# Altium schematic sheet Psoc.SchDoc
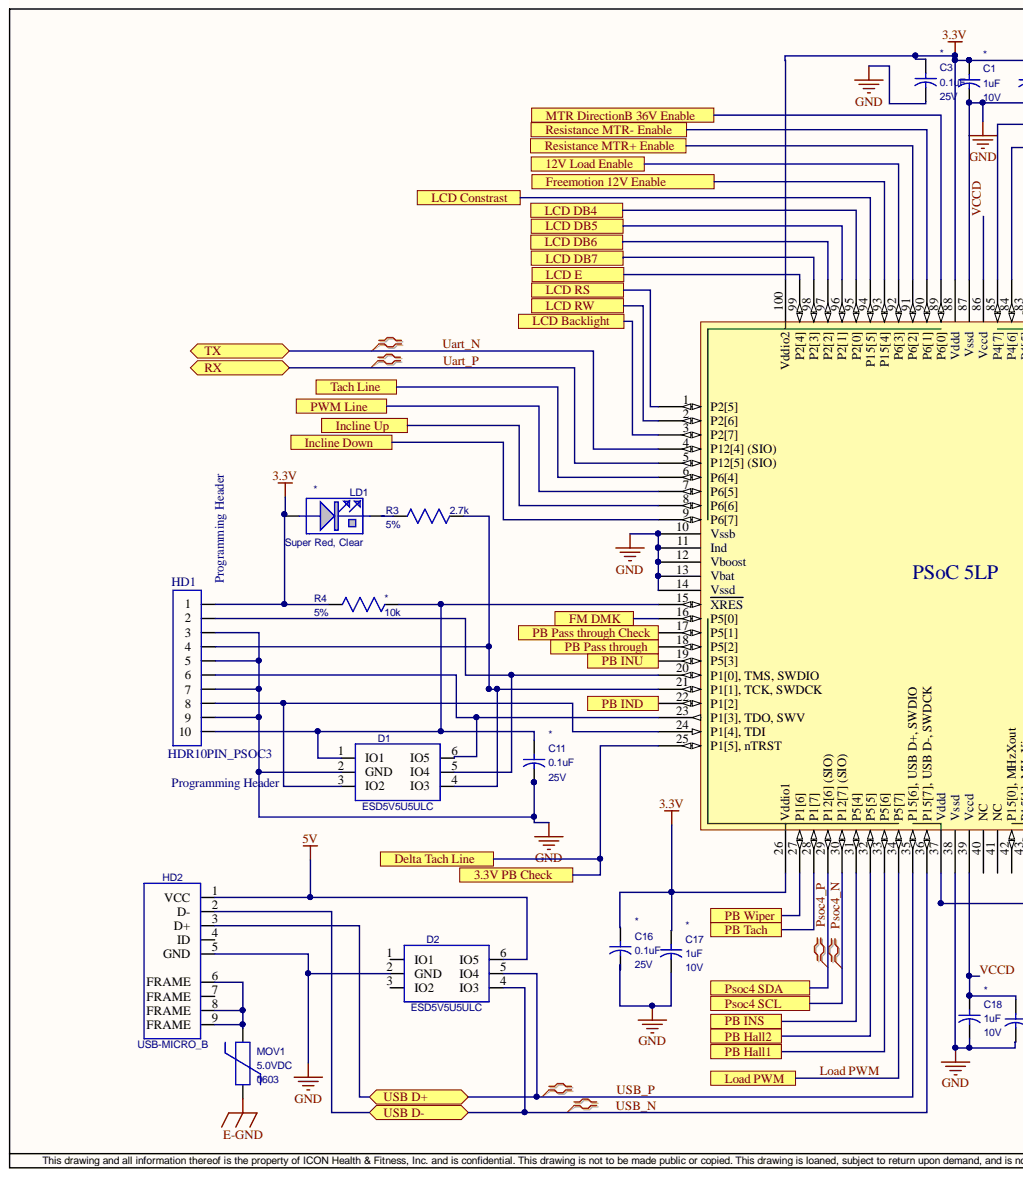
[diagram p0: Psoc.SchDoc, left]
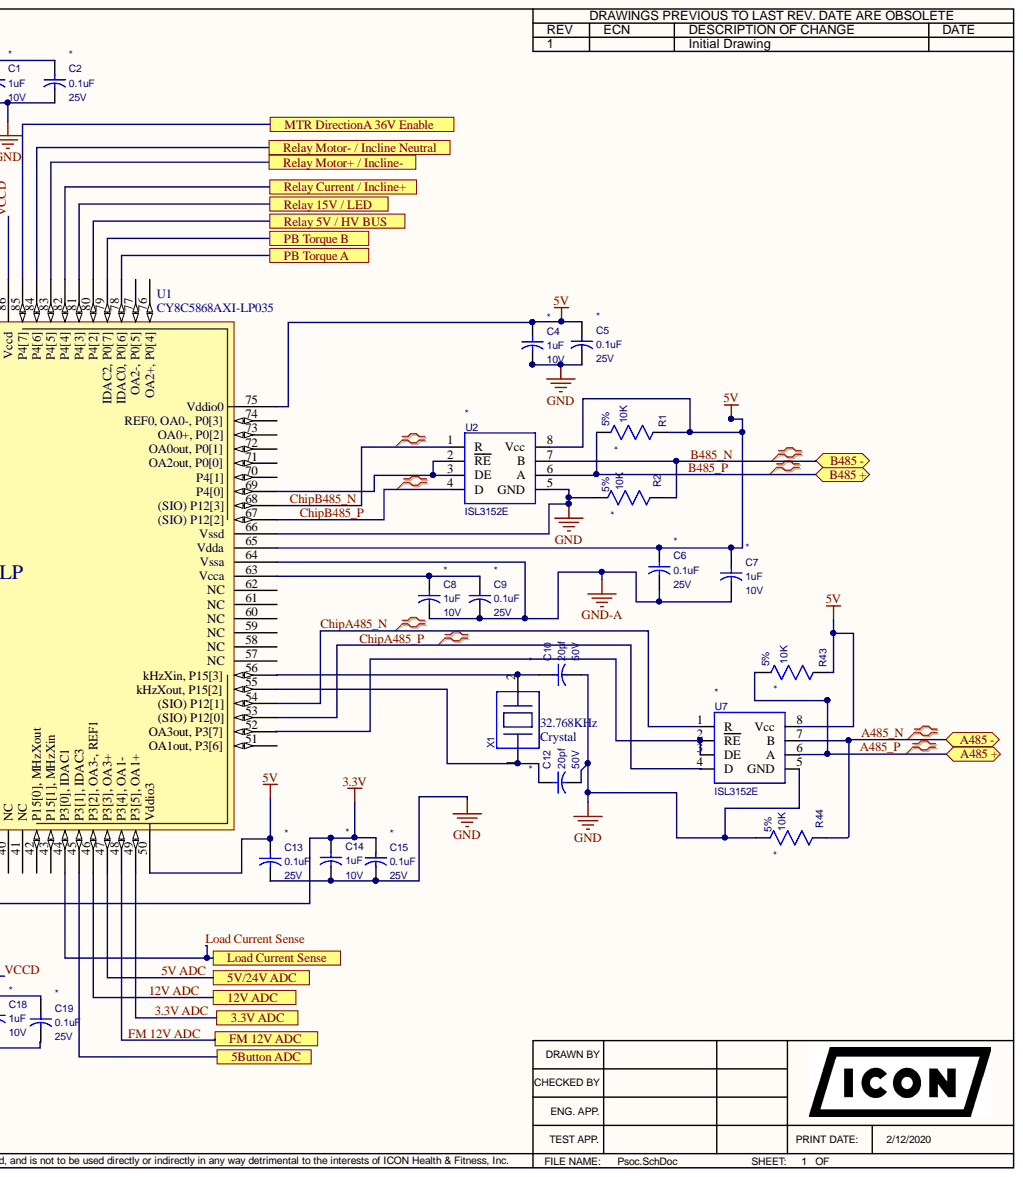
[diagram p1: Psoc.SchDoc, right]

## Parts
C1  value 1uF  Voltage: 10V  [0201]  (hidden comment: CAP_NON_POLAR_SMT)
C2  value 0.1uF  Voltage: 25V  [C0201]  (hidden comment: CAP_NON_POLAR_SMT)
C3  value 0.1uF  Voltage: 25V  [C0201]  (hidden comment: CAP_NON_POLAR_SMT)
C4  value 1uF  Voltage: 10V  [0201]  (hidden comment: CAP_NON_POLAR_SMT)
C5  value 0.1uF  Voltage: 25V  [C0201]  (hidden comment: CAP_NON_POLAR_SMT)
C6  value 0.1uF  Voltage: 25V  [C0201]  (hidden comment: CAP_NON_POLAR_SMT)
C7  value 1uF  Voltage: 10V  [0201]  (hidden comment: CAP_NON_POLAR_SMT)
C8  value 1uF  Voltage: 10V  [0201]  (hidden comment: CAP_NON_POLAR_SMT)
C9  value 0.1uF  Voltage: 25V  [C0201]  (hidden comment: CAP_NON_POLAR_SMT)
C10  value 20pf  Voltage: 50V  [0201_0603]  (hidden comment: 20pF_0201)
C11  value 0.1uF  Voltage: 25V  [C0201]  (hidden comment: CAP_NON_POLAR_SMT)
C12  value 20pf  Voltage: 50V  [0201_0603]  (hidden comment: 20pF_0201)
C13  value 0.1uF  Voltage: 25V  [C0201]  (hidden comment: CAP_NON_POLAR_SMT)
C14  value 1uF  Voltage: 10V  [0201]  (hidden comment: CAP_NON_POLAR_SMT)
C15  value 0.1uF  Voltage: 25V  [C0201]  (hidden comment: CAP_NON_POLAR_SMT)
C16  value 0.1uF  Voltage: 25V  [C0201]  (hidden comment: CAP_NON_POLAR_SMT)
C17  value 1uF  Voltage: 10V  [0201]  (hidden comment: CAP_NON_POLAR_SMT)
C18  value 1uF  Voltage: 10V  [0201]  (hidden comment: CAP_NON_POLAR_SMT)
C19  value 0.1uF  Voltage: 25V  [C0201]  (hidden comment: CAP_NON_POLAR_SMT)
D1  ESD5V5U5ULC  [SOT457-6]
D2  ESD5V5U5ULC  [SOT457-6]
HD1  HDR10PIN_PSOC3  ComponentType: Header  Notes: Programming Header  [HDR10PIN_PSOC3]
HD2  USB-MICRO_B  [HD_USB_MICRO_B_5PIN]
LD1  Color: Super Red, Clear  [0603_LED]  (hidden comment: 0603_RED)
MOV1  Package: 0603  Voltage: 5.0VDC  [0603 MOV]  (hidden comment: MOV 0603 5.5VDC)
R1  value 10K  Tolerance: 5%  [RC0603REFLOW-IPC]  (hidden comment: R0603REFLOW_5%)
R2  value 10K  Tolerance: 5%  [RC0603REFLOW-IPC]  (hidden comment: R0603REFLOW_5%)
R3  value 2.7k  Tolerance: 5%  [0402]  (hidden comment: Resistor_0402_5%)
R4  value 10k  Tolerance: 5%  [0402]  (hidden comment: RESISTOR_SMT_5%)
R43  value 10K  Tolerance: 5%  [RC0603REFLOW-IPC]  (hidden comment: R0603REFLOW_5%)
R44  value 10K  Tolerance: 5%  [RC0603REFLOW-IPC]  (hidden comment: R0603REFLOW_5%)
U1  CY8C5868AXI-LP035  [TQFP-100_16x16-MD]
U2  value ISL3152E  [MSOP8]  (hidden comment: IC_RS485_TRANSCEIVER)
U7  value ISL3152E  [MSOP8]  (hidden comment: IC_RS485_TRANSCEIVER)
X1  Frequency: 32.768KHz  XtalType: Crystal  [SMD2x1.2]  (hidden comment: 8MHZ_CRYSTAL_4PIN_SMT)

## Ports
3.3V ADC, 3.3V PB Check, 5Button ADC, 5V/24V ADC, 12V ADC, 12V Load Enable, A485 +, A485 -, B485 +, B485 -, Delta Tach Line, FM 12V ADC, FM DMK, Freemotion 12V Enable, Incline Down, Incline Up, LCD Backlight, LCD Constrast, LCD DB4, LCD DB5, LCD DB6, LCD DB7, LCD E, LCD RS, LCD RW, Load Current Sense, Load PWM, MTR DirectionA 36V Enable, MTR DirectionB 36V Enable, PB Hall1, PB Hall2, PB IND, PB INS, PB INU, PB Pass through, PB Pass through Check, PB Tach, PB Torque A, PB Torque B, PB Wiper, Psoc4 SCL, Psoc4 SDA, PWM Line, Relay 5V / HV BUS, Relay 15V / LED, Relay Current / Incline+, Relay Motor+ / Incline-, Relay Motor- / Incline Neutral, Resistance MTR+ Enable, Resistance MTR- Enable, RX, Tach Line, TX, USB D+, USB D-

## Nets
3.3V: C1.1 C2.1 C3.1 C14.1 C15.1 C16.1 C17.1 HD1.1 LD1.2 R4.2 U1.26(Vddio1) U1.37(Vddd) U1.88(Vddd) U1.100(Vddio2)
5V: C4.1 C5.1 C6.1 C7.1 C13.1 D2.6(IO5) HD2.1(VCC) R1.2 R43.2 U1.50(Vddio3) U1.65(Vdda) U1.75(Vddio0) U2.8(Vcc) U7.8(Vcc)
E-GND: MOV1.2
GND: C1.2 C2.2 C3.2 C4.2 C5.2 C10.2 C11.2 C12.2 C13.2 C14.2 C15.2 C16.2 C17.2 C18.2 C19.2 D1.2(GND) D2.2(GND) HD1.3 HD1.5 HD1.7 HD1.9 HD2.5(GND) R2.1 R44.1 U1.10(Vssb) U1.11(Ind) U1.12(Vboost) U1.13(Vbat) U1.14(Vssd) U1.38(Vssd) U1.66(Vssd) U1.87(Vssd) U2.5(GND) U7.5(GND)
GND-A: C6.2 C7.2 C8.2 C9.2 U1.64(Vssa)
3.3V ADC: U1.49(P3[5],_OA1+) ; port 3.3V ADC
3.3V PB Check: U1.25(P1[5],_nTRST) ; port 3.3V PB Check, port Delta Tach Line
5Button ADC: U1.45(P3[1],_IDAC3) ; port 5Button ADC
5V ADC: U1.47(P3[3],_OA3+) ; port 5V/24V ADC
12V ADC: U1.46(P3[2],_OA3-,_REF1) ; port 12V ADC
12V Load Enable: U1.92(P6[3]) ; port 12V Load Enable
A485_N: R44.2 U7.7(B)
A485_P: R43.1 U7.6(A)
B485_N: R2.2 U2.7(B)
B485_P: R1.1 U2.6(A)
ChipA485_N: U1.54((SIO)_P12[1]) U7.1(R)
ChipA485_P: U1.53((SIO)_P12[0]) U7.4(D)
ChipB485_N: U1.68((SIO)_P12[3]) U2.1(R)
ChipB485_P: U1.67((SIO)_P12[2]) U2.4(D)
FM 12V ADC: U1.48(P3[4],_OA1-) ; port FM 12V ADC
FM DMK: U1.16(P5[0]) ; port FM DMK
Freemotion 12V Enable: U1.93(P15[4]) ; port Freemotion 12V Enable
Incline Down: U1.9(P6[7]) ; port Incline Down
Incline Up: U1.8(P6[6]) ; port Incline Up
LCD Backlight: U1.3(P2[7]) ; port LCD Backlight
LCD Constrast: U1.94(P15[5]) ; port LCD Constrast
LCD DB4: U1.95(P2[0]) ; port LCD DB4
LCD DB5: U1.96(P2[1]) ; port LCD DB5
LCD DB6: U1.97(P2[2]) ; port LCD DB6
LCD DB7: U1.98(P2[3]) ; port LCD DB7
LCD E: U1.99(P2[4]) ; port LCD E
LCD RS: U1.1(P2[5]) ; port LCD RS
LCD RW: U1.2(P2[6]) ; port LCD RW
Load Current Sense: U1.44(P3[0],_IDAC1) ; port Load Current Sense
Load PWM: U1.34(P5[7]) ; port Load PWM
MTR DirectionA 36V Enable: U1.85(P4[7]) ; port MTR DirectionA 36V Enable
MTR DirectionB 36V Enable: U1.89(P6[0]) ; port MTR DirectionB 36V Enable
PB Hall1: U1.33(P5[6]) ; port PB Hall1
PB Hall2: U1.32(P5[5]) ; port PB Hall2
PB IND: U1.22(P1[2]) ; port PB IND
PB INS: U1.31(P5[4]) ; port PB INS
PB INU: U1.19(P5[3]) ; port PB INU
PB Pass through: U1.18(P5[2]) ; port PB Pass through
PB Pass through Check: U1.17(P5[1]) ; port PB Pass through Check
PB Tach: U1.28(P1[7]) ; port PB Tach
PB Torque A: U1.78(IDAC0,_P0[6]) ; port PB Torque A
PB Torque B: U1.79(IDAC2,_P0[7]) ; port PB Torque B
PB Wiper: U1.27(P1[6]) ; port PB Wiper
Psoc4_N: U1.30(P12[7]_(SIO)) ; port Psoc4 SCL
Psoc4_P: U1.29(P12[6]_(SIO)) ; port Psoc4 SDA
PWM Line: U1.7(P6[5]) ; port PWM Line
Relay 5V / HV BUS: U1.80(P4[2]) ; port Relay 5V / HV BUS
Relay 15V / LED: U1.81(P4[3]) ; port Relay 15V / LED
Relay Current / Incline+: U1.82(P4[4]) ; port Relay Current / Incline+
Relay Motor+ / Incline-: U1.83(P4[5]) ; port Relay Motor+ / Incline-
Relay Motor- / Incline Neutral: U1.84(P4[6]) ; port Relay Motor- / Incline Neutral
Tach Line: U1.6(P6[4]) ; port Tach Line
Uart_N: U1.4(P12[4]_(SIO)) ; port TX
Uart_P: U1.5(P12[5]_(SIO)) ; port RX
USB_N: D2.4(IO3) HD2.2(D-) U1.36(P15[7],_USB_D-,_SWDCK)
USB_P: D2.5(IO4) HD2.3(D+) U1.35(P15[6],_USB_D+,_SWDIO)
VCCD: C18.1 C19.1 U1.39(Vccd) U1.86(Vccd)
NetC8_1: C8.1 C9.1 U1.63(Vcca)
NetC10_1: C10.1 U1.56(kHzXin,_P15[3]) X1.2
NetC11_1: C11.1 D1.1(IO1) HD1.10 R4.1 U1.15(XRES)
NetC12_1: C12.1 U1.55(kHzXout,_P15[2]) X1.1
NetD1_3: D1.3(IO2) HD1.8 U1.24(P1[4],_TDI)
NetD1_4: D1.4(IO3) HD1.4 R3.1 U1.21(P1[1],_TCK,_SWDCK)
NetD1_5: D1.5(IO4) HD1.2 U1.20(P1[0],_TMS,_SWDIO)
NetD1_6: D1.6(IO5) HD1.6 U1.23(P1[3],_TDO,_SWV)
NetHD2_6: HD2.6(FRAME) HD2.8(FRAME) HD2.9(FRAME) MOV1.1
NetLD1_1: LD1.1 R3.2
NetU1_52: U1.52(OA3out,_P3[7]) U7.2(RE) U7.3(DE)
NetU1_69: U1.69(P4[0]) U2.2(RE) U2.3(DE)
NetU1_90: U1.90(P6[1])
NetU1_91: U1.91(P6[2])

Not connected: D2 1(IO1) 3(IO2); HD2 4(ID) 7(FRAME); U1 40(NC) 41(NC) 42(P15[0],_MHzXout) 43(P15[1],_MHzXin) 51(OA1out,_P3[6]) 57(NC) 58(NC) 59(NC) 60(NC) 61(NC) 62(NC) 70(P4[1]) 71(OA2out,_P0[0]) 72(OA0out,_P0[1]) 73(OA0+,_P0[2]) 74(REF0,_OA0-,_P0[3]) 76(OA2+,_P0[4]) 77(OA2-,_P0[5])

## Library data of the parts
C1 C4 C7 C8 C14 C17 C18 = 319156: Capacitor, 1uF, 10V, X5R, Ceramic, -20/+20%, Non-Polar, 0201, 2, Yageo: CC0201MRX5R6BB105 or equivalent; Database Table Name: AltiumComponents; CapType: X5R; Component Kind: Standard; ComponentLink4Description: PDM Parts Maintenance; ComponentLink4URL: https://pdm.iconfitness.com/PDM/EBOM/PartsMaintenance/PartsMaintenance.aspx?Editplidx=319156; ComponentType: Capacitor; Composition: Ceramic; Cost: 0; Package: 0201; Pins: 2; plIDX: 319156; Polarity: Non-Polar; Tolerance: -20/+20%; Vendor: Yageo: CC0201MRX5R6BB105 or equivalent; CheckedBy: Sam Rhoufiry; CreatedBy: Steven Zhou; LastUpdatedBy: Steven Zhou; Library Name: bome.DbLib
C2 C3 C5 C6 C9 C11 C13 C15 C16 C19 = 304055: Capacitor, 0.1uF, 25V, X5R, Ceramic, -20/+20%, Non-Polar, 0201, Fenghua: 0201X104M250NT or equivalent; Database Table Name: AltiumComponents; CapType: X5R; Component Kind: Standard; ComponentLink4Description: PDM Parts Maintenance; ComponentLink4URL: https://pdm.iconfitness.com/PDM/EBOM/PartsMaintenance/PartsMaintenance.aspx?Editplidx=304055; ComponentType: Capacitor; Composition: Ceramic; Cost: 0; Package: 0201; plIDX: 304055; Polarity: Non-Polar; Tolerance: -20/+20%; Vendor: Fenghua: 0201X104M250NT or equivalent; CheckedBy: Sam Rhoufiry; CreatedBy: Sara Lin; LastUpdatedBy: Steven Zhou; Library Name: bome.DbLib
C10 C12 = 365361: Capacitor, 20pf, 50V, GRM, Ceramic, ±5%, Non-Polar, 0201, 490-11315-1-ND; Database Table Name: AltiumComponents; CapType: GRM; Component Kind: Standard; ComponentLink4Description: PDM Parts Maintenance; ComponentLink4URL: https://pdm.iconfitness.com/PDM/EBOM/PartsMaintenance/PartsMaintenance.aspx?Editplidx=365361; ComponentType: Capacitor; Composition: Ceramic; Cost: 0; ModifyDate: 01/03/2020; Package: 0201; plIDX: 365361; Tolerance: ±5%; Vendor: 490-11315-1-ND; CreatedBy: Rich Johns; LastUpdatedBy: Rich Johns; Library Name: bome.DbLib
D1 D2 = 325030: Diode, ESD5V5U5ULC, TVS, 5V, TSOP6, Infineon: ESD5V5U5ULC; Database Table Name: AltiumComponents; IDFCOMP: 0; Component Kind: Standard; ComponentLink1Description: Datasheet; ComponentLink1URL: \\iconfitness.com\loganfiles\RND Departments\electronics\accel_lib\datasheets\Infineon-ESD5V5U5ULC-DS-v01_04-EN.pdf; ComponentLink4Description: PDM Parts Maintenance; ComponentLink4URL: https://pdm.iconfitness.com/PDM/EBOM/PartsMaintenance/PartsMaintenance.aspx?Editplidx=325030; ComponentType: Diode; Cost: 0.08; Datasheet: \\langley\electronics\accel_lib\datasheets\D\PESDXS4UD-NXP.pdf; DiodeType: TVS; ModifyDate: 07/31/2009 12:30:23; Package: TSOP6; plIDX: 325030; Value: ESD5V5U5ULC; Vendor: Infineon: ESD5V5U5ULC; Voltage: 5V; CheckedBy: T. Hayes; CreatedBy: Rui Zhang; LastUpdatedBy: Rui Zhang; Library Name: bome.DbLib
HD1 = 18843: Header, 10 Pins, Samtec: FTSH-105-01-L-DV-K; Database Table Name: AltiumComponents; Component Kind: Standard; ComponentLink4Description: PDM Parts Maintenance; ComponentLink4URL: https://pdm.iconfitness.com/PDM/EBOM/PartsMaintenance/PartsMaintenance.aspx?Editplidx=18843; Cost: 0.15; Pin: 10-pin; Pins: 10; plIDX: 18843; Value: FTSH-105-01-L-DV-K; Vendor: Samtec: FTSH-105-01-L-DV-K; Library Name: bome.DbLib
HD2 = 301496: Header, 9 Pins, NA, USB Type B Micro Receptacle, Surface Mount, Right Angle, FCI: 10118192-0001LF; Database Table Name: AltiumComponents; IDF_COMP: 1; ComponentHeight: 156mil; Component Kind: Standard; ComponentLink4Description: PDM Parts Maintenance; ComponentLink4URL: https://pdm.iconfitness.com/PDM/EBOM/PartsMaintenance/PartsMaintenance.aspx?Editplidx=301496; ComponentType: Header; Cost: 0.14; HeaderType: USB Type B Micro Receptacle, Surface Mount, Right Angle; LeadSpacing: NA; ModifyDate: 10/12/10; Pins: 9; plIDX: 301496; Value: 10118192-0001LF; Vendor: FCI: 10118192-0001LF; CheckedBy: T. Hayes; CreatedBy: Rui Zhang; Datasheet: G:\accel_lib\datasheets\USB-Hirose.pdf; LastUpdatedBy: Rui Zhang; Library Name: bome.DbLib
LD1 = 9506: LED, Super Red, Clear, Rectangle, Diffused, 0603, Vendor: Lite-on, LTST-C190KRKT or equivalent; Database Table Name: AltiumComponents; IDF_COMP: 1; PackageOutlineLayer: PackageOutline; ComponentHeight: 32mil; Component Kind: Standard; ComponentLink4Description: PDM Parts Maintenance; ComponentLink4URL: https://pdm.iconfitness.com/PDM/EBOM/PartsMaintenance/PartsMaintenance.aspx?Editplidx=9506; ComponentType: LED; Cost: 0.015; LEDType: Diffused; ModifyDate: 02/08/2007 16:42:04; Package: 0603; plIDX: 9506; Shape: Rectangle; Vendor: Lite-on; VendorNumber: LTST-C190KRKT or equivalent; CheckedBy: Elton John; Datasheet: G:\accel_lib\datasheets\LTST-C190KRKT.pdf; HelpURL: G:\accel_lib\datasheets\LTST-C190KRKT.pdf; LastUpdatedBy: Mike Tyger; Library Name: bome.DbLib
MOV1 = 307689: MOV, 5.0VDC, 25V clamp, 0603, 2, Bourns: CG0603MLC-05LE; Database Table Name: AltiumComponents; Notes: Alternate - Comchip: CPDU5V0; Component Kind: Standard; ComponentLink4Description: PDM Parts Maintenance; ComponentLink4URL: https://pdm.iconfitness.com/PDM/EBOM/PartsMaintenance/PartsMaintenance.aspx?Editplidx=307689; ComponentType: MOV; Cost: 0.07; Pins: 2; plIDX: 307689; Value: 25V clamp; Vendor: Bourns: CG0603MLC-05LE; CheckedBy: Wetfart McShart; CreatedBy: Rui Zhang; Datasheet: G:\accel_lib\datasheets\MHS-Littelfuse.pdf; HelpURL: G:\accel_lib\datasheets\MHS-Littelfuse.pdf; LastUpdatedBy: Rui Zhang; Library Name: bome.DbLib
R1 R2 R43 R44 = 2051: Resistor, 10K, ThickFilm, 5%, 0603, 1/10W, Any; Database Table Name: AltiumComponents; Notes: .; Component Kind: Standard; ComponentLink4Description: PDM Parts Maintenance; ComponentLink4URL: https://pdm.iconfitness.com/PDM/EBOM/PartsMaintenance/PartsMaintenance.aspx?Editplidx=2051; ComponentType: Resistor; Composition: ThickFilm; Cost: 0.001; ModifyDate: 03/02/2004 17:13:13; Package: 0603; plIDX: 2051; Vendor: Any; Wattage: 1/10W; CheckedBy: Michael Angeli; Library Name: bome.DbLib
R3 = 19592: Resistor, 2.7k, ThickFilm, 5%, 0402, 1/10W, 2, Any; Database Table Name: AltiumComponents; Component Kind: Standard; ComponentLink4Description: PDM Parts Maintenance; ComponentLink4URL: https://pdm.iconfitness.com/PDM/EBOM/PartsMaintenance/PartsMaintenance.aspx?Editplidx=19592; ComponentType: Resistor; Composition: ThickFilm; Cost: 0.0004; ModifyDate: 4/13/10; Package: 0402; Pins: 2; plIDX: 19592; Vendor: Any; Wattage: 1/10W; CheckedBy: gdeguzman; LastUpdatedBy: Mourly Thov; Library Name: bome.DbLib
R4 = 19590: Resistor, 10k, ThickFilm, 5%, 0402, 1/10W, Any; Database Table Name: AltiumComponents; Component Kind: Standard; ComponentLink4Description: PDM Parts Maintenance; ComponentLink4URL: https://pdm.iconfitness.com/PDM/EBOM/PartsMaintenance/PartsMaintenance.aspx?Editplidx=19590; ComponentType: Resistor; Composition: ThickFilm; Cost: 0.0004; Package: 0402; plIDX: 19590; Vendor: Any; Wattage: 1/10W; CheckedBy: gdeguzman; LastUpdatedBy: Mike Tyger; Library Name: bome.DbLib
U1 = 263342: IC, CY8C5868AXI-LP035, Microcontroller, TQFP, 100 Pins, Cypress: CY8C5868AXI-LP035; Database Table Name: AltiumComponents; MFGPN: CY8C5xxxAXx-LPxxx; MFN: Cypress Semiconductor; ChipType: Microcontroller; Component Kind: Standard; ComponentLink4Description: PDM Parts Maintenance; ComponentLink4URL: https://pdm.iconfitness.com/PDM/EBOM/PartsMaintenance/PartsMaintenance.aspx?Editplidx=263342; ComponentType: IC; Cost: 4; MFG: Cypress; Package: TQFP; Pins: 100; plIDX: 263342; Value: CY8C5868AXI-LP035; Vendor: Cypress: CY8C5868AXI-LP035; VendorName: Cypress; VendorNumber: CY8C5868AXI-LP035; VPN: CY8C5xxxAXx-LPxxx; CreatedBy: Jacob Cordingley; LastUpdatedBy: Paul Skinner; Library Name: bome.DbLib
U2 U7 = 342170: IC, ISL3152E, Differential Transceiver, MSOP, 8 Pins, Intersil: ISL3152EIUZ-T or Equivalent; Database Table Name: AltiumComponents; ChipType: Differential Transceiver; Component Kind: Standard; ComponentLink1Description: Datasheet; ComponentLink1URL: https://www.intersil.com/content/dam/Intersil/documents/isl3/isl3150e-52e-53e-55e-56e-58e.pdf; ComponentLink4Description: PDM Parts Maintenance; ComponentLink4URL: https://pdm.iconfitness.com/PDM/EBOM/PartsMaintenance/PartsMaintenance.aspx?Editplidx=342170; ComponentType: IC; Cost: 0; Package: MSOP; Pins: 8; plIDX: 342170; Vendor: Intersil: ISL3152EIUZ-T or Equivalent; CreatedBy: Mike Tyger; LastUpdatedBy: Mike Tyger; Library Name: bome.DbLib
X1 = 101741: Xtal, 32.768kHz, +/-20ppm, SMT, SMD 2mmx1.2mm, 2, Crystal, 32.768KHz, TXC: 9HT11-32.768KDZF; Database Table Name: AltiumComponents; ComponentHeight: 32mil; Component Kind: Standard; ComponentLink4Description: PDM Parts Maintenance; ComponentLink4URL: https://pdm.iconfitness.com/PDM/EBOM/PartsMaintenance/PartsMaintenance.aspx?Editplidx=101741; ComponentType: Xtal; Cost: 0.155; Datasheet: \\langley\electronics\accel_lib\datasheets\T7-32 768K-12 5P-5.pdf; LeadSpacing: SMT; ModifyDate: 9/12/12; Package: SMD 2mmx1.2mm; Pins: 2; plIDX: 101741; Tolerance: +/-20ppm; Value: 32.768kHz; Vendor: TXC: 9HT11-32.768KDZF; LastUpdatedBy: Steven Zhou; Library Name: bome.DbLib
